# Altium schematic sheet connectors.SchDoc
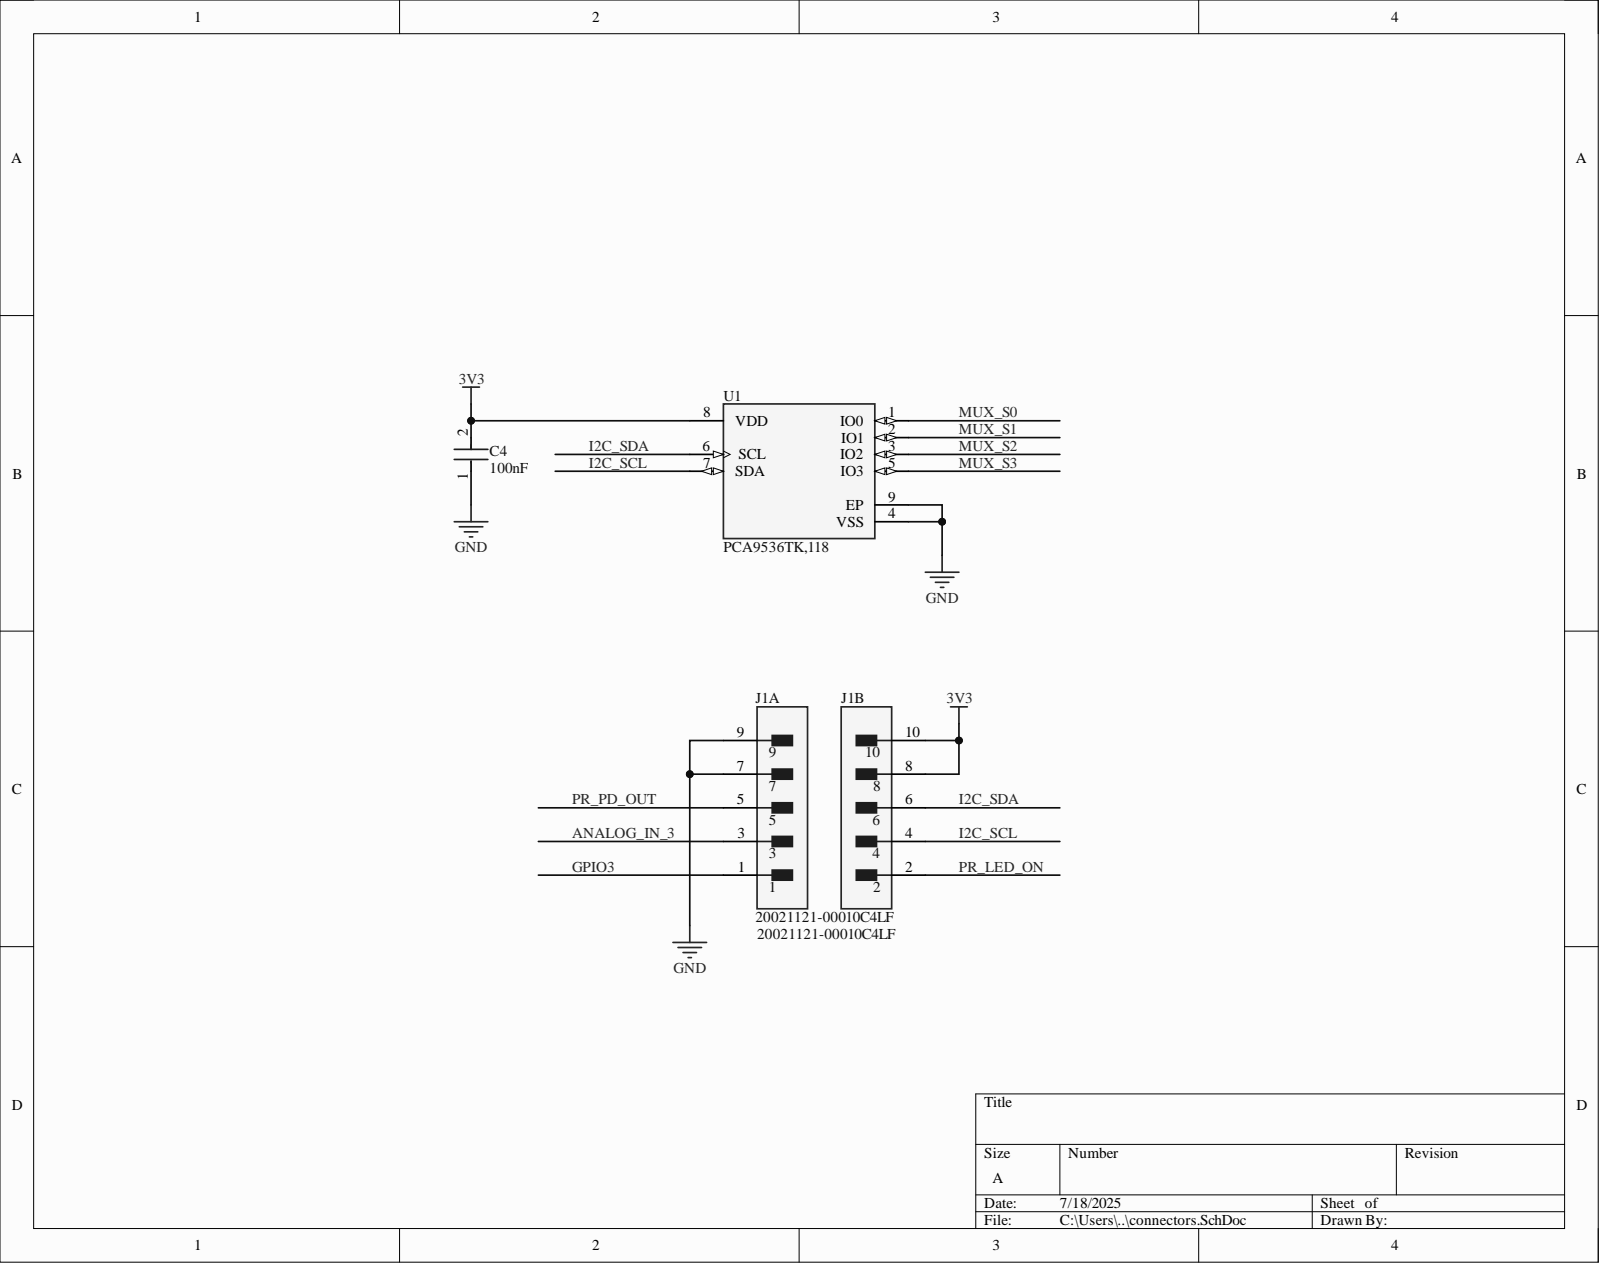
[diagram p0: connectors.SchDoc]

## Parts
C4  Capacitance: 100nF  [FP-GRM15-MFG]  (hidden comment: GRM155R71C104KA88D)
J1  20021121-00010C4LF  [FP-20021121-00010C4LF-MFG]  (parts A,B)
U1  PCA9536TK,118  [FP-SOT908-1-MFG]

## Nets
3V3: C4.2 J1.8 J1.10 U1.8(VDD)
GND: C4.1 J1.7 J1.9 U1.4(VSS) U1.9(EP)
ANALOG_IN_3: J1.3
GPIO3: J1.1
I2C_SCL: J1.4 U1.7(SDA)
I2C_SDA: J1.6 U1.6(SCL)
MUX_S0: U1.1(IO0)
MUX_S1: U1.2(IO1)
MUX_S2: U1.3(IO2)
MUX_S3: U1.5(IO3)
PR_LED_ON: J1.2
PR_PD_OUT: J1.5

## Library data of the parts
C4 = CMP-2100-03641-2: CAP CER 0.1UF 16V X7R 0402; Manufacturer: Murata; Material: Ceramic; Standoff Height: 0mm; Tolerance: 10%; Value: 0.10μF; Voltage Rating: 16Vdc; Category: Cap; Component Kind: Standard; ComponentLink2Description: Datasheet; ComponentLink2URL: https://search.murata.co.jp/Ceramy/image/img/A01X/G101/ENG/GRM155R71H471KA01-01A.pdf; Device Class L1: Passive Components; Device Class L2: Capacitors; Device Class L3: Ceramic Capacitors; Digikey Description: CAP CER 0.1UF 16V X7R 0402; Height: 0.55mm; Ipc Land Pattern Name: CAPC10050X219; Lead Free: yes; Library Name: Altium Content Vault; Manufacturer Part Number: GRM155R71C104KA88D; Mouser Description: 0402 0.1 uF 16V ±10 % Tolerance X7R SMT Multilayer Ceramic Capacitor; Octopart: https://octopart.com/grm155r71c104ka88d-murata-196880; Package: GRM15; RoHS: TRUE; Temperature: -55°C to +125°C; Temperature Characteristic: X7R; Temperature Range High: +125°C; Temperature Range Low: -55°C
J1 = CMP-15126-126517171-1: 20021121-00010C4LF Conn Unshrouded Header HDR 10 POS 1.27mm Solder ST Top Entry SMD Minitek127® T/R; Connector Type: Header, Male Pin; Contact Resistance: 30mΩ; Current Rating: 1A; Gender: Male; Insulation Resistance: 100MΩ; Mating Post Length: 3.05mm; Max Voltage Rating (AC): 125V; Number of Contacts: 10; Stack Height: 3mm; Color: Black; Component Kind: Standard; Contact Material: Copper, Gold; Contact Mating Length: 3.048mm; Contact Plating: Gold; Depth: 3.4mm; Height: 4.8mm; Housing Color: Black; Housing Material: Thermoplastic; Length: 6.35mm; Library Name: Altium Content Vault; Max Operating Temperature: 85°C; Min Operating Temperature: -55°C; Mount: Surface Mount; NexarPartId: 126517171; Number of Pins: 10; Number of Positions: 10; Number of Rows: 2; Orientation: Straight; Pitch: 1.27mm; Radiation Hardening: No; REACH SVHC: No SVHC; RoHS: Compliant; Row Spacing: 1.27mm; Termination: Solder; Voltage Rating: 125V
U1 = CMP-10112-000023-1: IC I/O EXPANDER I2C 4B 8HVSON; Frequency: 400kHz; Max Power Dissipation: 200mW; Nominal Supply Current: 85mA; Number of I/Os: 4; Operating Supply Voltage: 5.5V; Output Current: 50mA; Case/Package: HVSON; Component Kind: Standard; Contact Plating: Gold; Interface: I2C; Lead Free: Lead Free; Library Name: Altium Content Vault; Max Frequency: 400kHz; Max Operating Temperature: 85°C; Min Operating Temperature: -40°C; Mount: Surface Mount; Number of Pins: 8; Operating Supply Current: 85mA; Package Quantity: 1; Packaging: Tape and Reel; RoHS Compliant: Yes; Series: -; Supply Current: 85mA; Supply Voltage-Nom: 5.5V
